# Altium schematic sheet main.SchDoc(main)
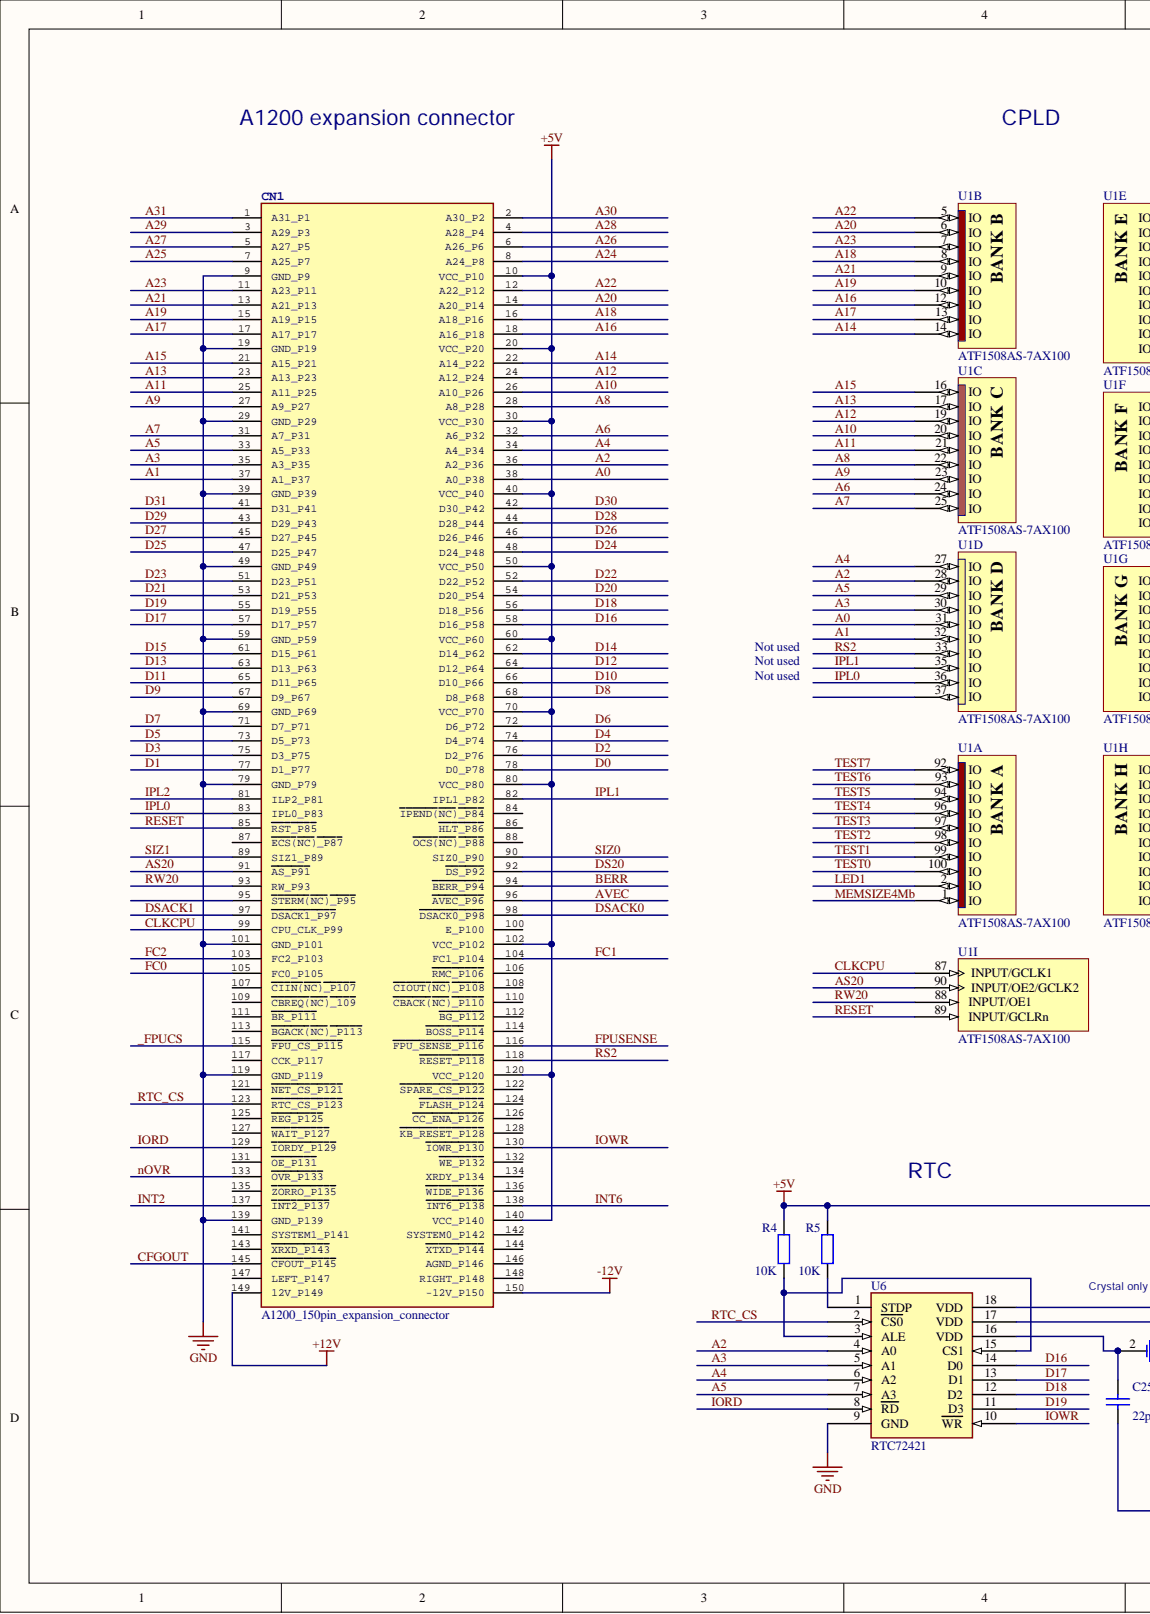
[diagram p0: main.SchDoc, left]
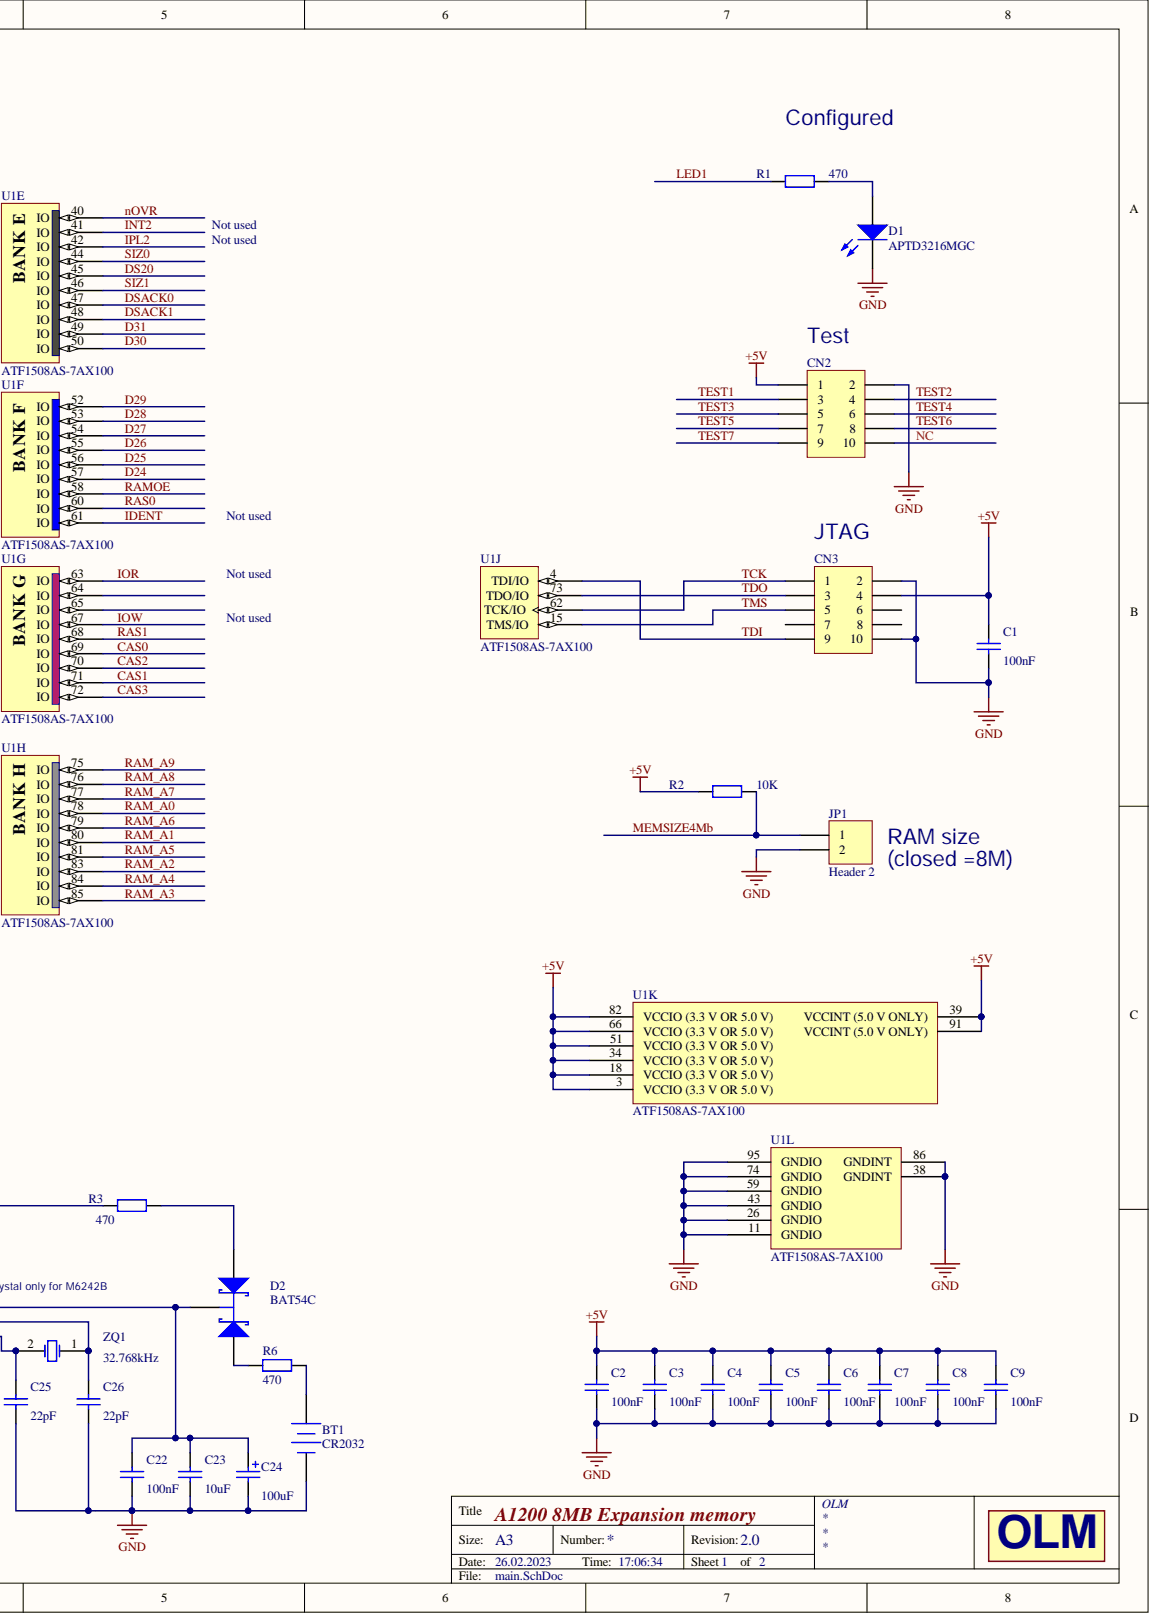
[diagram p1: main.SchDoc, right]

## Parts
BT1  CR2032  [BATTERY_HOLDER_2032]
C1  value 100nF  [SM/C_0603]  (hidden comment: Cap)
C2  value 100nF  [SM/C_0603]  (hidden comment: Cap)
C3  value 100nF  [SM/C_0603]  (hidden comment: Cap)
C4  value 100nF  [SM/C_0603]  (hidden comment: Cap)
C5  value 100nF  [SM/C_0603]  (hidden comment: Cap)
C6  value 100nF  [SM/C_0603]  (hidden comment: Cap)
C7  value 100nF  [SM/C_0603]  (hidden comment: Cap)
C8  value 100nF  [SM/C_0603]  (hidden comment: Cap)
C9  value 100nF  [SM/C_0603]  (hidden comment: Cap)
C22  value 100nF  [SM/C_0603]  (hidden comment: Cap)
C23  value 10uF  [SM/C_1206]  (hidden comment: Cap)
C24  value 100uF  [CAP_POL_CYL_5x11]  (hidden comment: Cap Pol)
C25  value 22pF  [SM/C_0603]  (hidden comment: Capacitor)
C26  value 22pF  [SM/C_0603]  (hidden comment: Capacitor)
CN1  value A1200_150pin_expansion_connector  [A1200_CON150]  (hidden comment: A1200-EXPANSION_SLOT)
CN2  Header 5X2H  [PLD-10]  (value not drawn)
CN3  Header 5X2H  [Header-2x5_2.54mm_RA]  (value not drawn)
D1  value APTD3216MGC  [SM/LED_0805_21_BLUE]  (hidden comment: Светодиод)
D2  BAT54C  [SM/SOT23_123]
JP1  Header 2  [HDR1X2]
R1  value 470  [SM/R_0603]  (hidden comment: Резистор)
R2  value 10K  [SM/R_0603]  (hidden comment: Резистор)
R3  value 470  [SM/R_0603]  (hidden comment: Резистор)
R4  value 10K  [SM/R_0603]  (hidden comment: Резистор)
R5  value 10K  [SM/R_0603]  (hidden comment: Резистор)
R6  value 470  [SM/R_0603]  (hidden comment: Резистор)
U1  ATF1508AS-7AX100  [TQFP100_16mm]  (parts A,B,C,D,E,F,G,H,I,J,K,L)
U6  RTC72421  [DIP.100/18/W.300/L.900]
ZQ1  value 32.768kHz  [CRYSTAL_CLOCK]  (hidden comment: XTAL)

## Nets
+5V: C1.2 C2.2 C3.2 C4.2 C5.2 C6.2 C7.2 C8.2 C9.2 CN1.10(VCC_P10) CN1.20(VCC_P20) CN1.30(VCC_P30) CN1.40(VCC_P40) CN1.50(VCC_P50) CN1.60(VCC_P60) CN1.70(VCC_P70) CN1.80(VCC_P80) CN1.102(VCC_P102) CN1.120(VCC_P120) CN1.140(VCC_P140) CN2.1 CN3.4 R2.2 R3.2 R4.1 R5.1 U1.3(VCCIO_(3.3_V_OR_5.0_V)) U1.18(VCCIO_(3.3_V_OR_5.0_V)) U1.34(VCCIO_(3.3_V_OR_5.0_V)) U1.39(VCCINT_(5.0_V_ONLY)) U1.51(VCCIO_(3.3_V_OR_5.0_V)) U1.66(VCCIO_(3.3_V_OR_5.0_V)) U1.82(VCCIO_(3.3_V_OR_5.0_V)) U1.91(VCCINT_(5.0_V_ONLY))
+12V: CN1.149(12V_P149)
-12V: CN1.150(-12V_P150)
GND: BT1.2 C1.1 C2.1 C3.1 C4.1 C5.1 C6.1 C7.1 C8.1 C9.1 C22.1 C23.1 C24.2 C25.1 C26.1 CN1.9(GND_P9) CN1.19(GND_P19) CN1.29(GND_P29) CN1.39(GND_P39) CN1.49(GND_P49) CN1.59(GND_P59) CN1.69(GND_P69) CN1.79(GND_P79) CN1.101(GND_P101) CN1.119(GND_P119) CN1.139(GND_P139) CN2.2 CN3.2 CN3.10 D1.2 JP1.2 U1.11(GNDIO) U1.26(GNDIO) U1.38(GNDINT) U1.43(GNDIO) U1.59(GNDIO) U1.74(GNDIO) U1.86(GNDINT) U1.95(GNDIO) U6.9(GND)
_FPUCS: CN1.115(FPU_CS_P115)
A0: CN1.38(A0_P38) U1.31(IO)
A1: CN1.37(A1_P37) U1.32(IO)
A2: CN1.36(A2_P36) U1.28(IO) U6.4(A0)
A3: CN1.35(A3_P35) U1.30(IO) U6.5(A1)
A4: CN1.34(A4_P34) U1.27(IO) U6.6(A2)
A5: CN1.33(A5_P33) U1.29(IO) U6.7(A3)
A6: CN1.32(A6_P32) U1.24(IO)
A7: CN1.31(A7_P31) U1.25(IO)
A8: CN1.28(A8_P28) U1.22(IO)
A9: CN1.27(A9_P27) U1.23(IO)
A10: CN1.26(A10_P26) U1.20(IO)
A11: CN1.25(A11_P25) U1.21(IO)
A12: CN1.24(A12_P24) U1.19(IO)
A13: CN1.23(A13_P23) U1.17(IO)
A14: CN1.22(A14_P22) U1.14(IO)
A15: CN1.21(A15_P21) U1.16(IO)
A16: CN1.18(A16_P18) U1.12(IO)
A17: CN1.17(A17_P17) U1.13(IO)
A18: CN1.16(A18_P16) U1.8(IO)
A19: CN1.15(A19_P15) U1.10(IO)
A20: CN1.14(A20_P14) U1.6(IO)
A21: CN1.13(A21_P13) U1.9(IO)
A22: CN1.12(A22_P12) U1.5(IO)
A23: CN1.11(A23_P11) U1.7(IO)
A24: CN1.8(A24_P8)
A25: CN1.7(A25_P7)
A26: CN1.6(A26_P6)
A27: CN1.5(A27_P5)
A28: CN1.4(A28_P4)
A29: CN1.3(A29_P3)
A30: CN1.2(A30_P2)
A31: CN1.1(A31_P1)
AS20: CN1.91(AS_P91) U1.90(INPUT/OE2/GCLK2)
AVEC: CN1.96(AVEC_P96)
BERR: CN1.94(BERR_P94)
CAS0: U1.69(IO)
CAS1: U1.71(IO)
CAS2: U1.70(IO)
CAS3: U1.72(IO)
CFGOUT: CN1.145(CFOUT_P145)
CLKCPU: CN1.99(CPU_CLK_P99) U1.87(INPUT/GCLK1)
D0: CN1.78(D0_P78)
D1: CN1.77(D1_P77)
D2: CN1.76(D2_P76)
D3: CN1.75(D3_P75)
D4: CN1.74(D4_P74)
D5: CN1.73(D5_P73)
D6: CN1.72(D6_P72)
D7: CN1.71(D7_P71)
D8: CN1.68(D8_P68)
D9: CN1.67(D9_P67)
D10: CN1.66(D10_P66)
D11: CN1.65(D11_P65)
D12: CN1.64(D12_P64)
D13: CN1.63(D13_P63)
D14: CN1.62(D14_P62)
D15: CN1.61(D15_P61)
D16: CN1.58(D16_P58) U6.14(D0)
D17: CN1.57(D17_P57) U6.13(D1)
D18: CN1.56(D18_P56) U6.12(D2)
D19: CN1.55(D19_P55) U6.11(D3)
D20: CN1.54(D20_P54)
D21: CN1.53(D21_P53)
D22: CN1.52(D22_P52)
D23: CN1.51(D23_P51)
D24: CN1.48(D24_P48) U1.57(IO)
D25: CN1.47(D25_P47) U1.56(IO)
D26: CN1.46(D26_P46) U1.55(IO)
D27: CN1.45(D27_P45) U1.54(IO)
D28: CN1.44(D28_P44) U1.53(IO)
D29: CN1.43(D29_P43) U1.52(IO)
D30: CN1.42(D30_P42) U1.50(IO)
D31: CN1.41(D31_P41) U1.49(IO)
DS20: CN1.92(DS_P92) U1.45(IO)
DSACK0: CN1.98(DSACK0_P98) U1.47(IO)
DSACK1: CN1.97(DSACK1_P97) U1.48(IO)
FC0: CN1.105(FC0_P105)
FC1: CN1.104(FC1_P104)
FC2: CN1.103(FC2_P103)
FPUSENSE: CN1.116(FPU_SENSE_P116)
IDENT: U1.61(IO)
INT2: CN1.137(INT2_P137) U1.41(IO)
INT6: CN1.138(INT6_P138)
IOR: U1.63(IO)
IORD: CN1.129(IORDY_P129) U6.8(RD)
IOW: U1.67(IO)
IOWR: CN1.130(IOWR_P130) U6.10(WR)
IPL0: CN1.83(IPL0_P83) U1.36(IO)
IPL1: CN1.82(IPL1_P82) U1.35(IO)
IPL2: CN1.81(ILP2_P81) U1.42(IO)
LED1: R1.2 U1.2(IO)
MEMSIZE4MB: JP1.1 R2.1 U1.1(IO)
NC: CN2.10
NOVR: CN1.133(OVR_P133) U1.40(IO)
RAM_A0: U1.78(IO)
RAM_A1: U1.80(IO)
RAM_A2: U1.83(IO)
RAM_A3: U1.85(IO)
RAM_A4: U1.84(IO)
RAM_A5: U1.81(IO)
RAM_A6: U1.79(IO)
RAM_A7: U1.77(IO)
RAM_A8: U1.76(IO)
RAM_A9: U1.75(IO)
RAMOE: U1.58(IO)
RAS0: U1.60(IO)
RAS1: U1.68(IO)
RESET: CN1.85(RST_P85) U1.89(INPUT/GCLRn)
RS2: CN1.118(RESET_P118) U1.33(IO)
RTC_CS: CN1.123(RTC_CS_P123) U6.2(CS0)
RW20: CN1.93(RW_P93) U1.88(INPUT/OE1)
SIZ0: CN1.90(SIZ0_P90) U1.44(IO)
SIZ1: CN1.89(SIZ1_P89) U1.46(IO)
TCK: CN3.1 U1.62(TCK/IO)
TDI: CN3.9 U1.4(TDI/IO)
TDO: CN3.3 U1.73(TDO/IO)
TEST0: U1.100(IO)
TEST1: CN2.3 U1.99(IO)
TEST2: CN2.4 U1.98(IO)
TEST3: CN2.5 U1.97(IO)
TEST4: CN2.6 U1.96(IO)
TEST5: CN2.7 U1.94(IO)
TEST6: CN2.8 U1.93(IO)
TEST7: CN2.9 U1.92(IO)
TMS: CN3.5 U1.15(TMS/IO)
NetBT1_1: BT1.1 R6.1
NetC22_2: C22.2 C23.2 C24.1 D2.3 U6.18(VDD)
NetC25_2: C25.2 U6.16(VDD) ZQ1.2
NetC26_2: C26.2 U6.17(VDD) ZQ1.1
NetD1_1: D1.1 R1.1
NetD2_1: D2.1 R3.1
NetD2_2: D2.2 R6.2
NetR4_2: R4.2 U6.3(ALE) U6.15(CS1)
NetR5_2: R5.2 U6.1(STDP)

Not connected: CN1 84(IPEND(NC)_P84) 86(HLT_P86) 87(ECS(NC)_P87) 88(OCS(NC)_P88) 95(STERM(NC)_P95) 100(E_P100) 106(RMC_P106) 107(CIIN(NC)_P107) 108(CIOUT(NC)_P108) 109(CBREQ(NC)_109) 110(CBACK(NC)_P110) 111(BR_P111) 112(BG_P112) 113(BGACK(NC)_P113) 114(BOSS_P114) 117(CCK_P117) 121(NET_CS_P121) 122(SPARE_CS_P122) 124(FLASH_P124) 125(REG_P125) 126(CC_ENA_P126) 127(WAIT_P127) 128(KB_RESET_P128) 131(OE_P131) 132(WE_P132) 134(XRDY_P134) 135(ZORRO_P135) 136(WIDE_P136) 141(SYSTEM1_P141) 142(SYSTEM0_P142) 143(XRXD_P143) 144(XTXD_P144) 146(AGND_P146) 147(LEFT_P147) 148(RIGHT_P148); CN3 6 7 8; U1 37(IO) 64(IO) 65(IO)

## Library data of the parts
BT1 = Battery: Multicell Battery; Component Kind: Standard; LatestRevisionDate: 17-Jul-2002; LatestRevisionNote: Re-released for DXP Platform.; Library Name: Miscellaneous Devices.IntLib; PackageDescription: Battery; 2 Leads; PackageReference: BAT-2; Published: 24-Mar-1999; Publisher: Altium Limited
C1-C9 C22 C23 = Cap ceramic: CAP-100Nf; Component Kind: Standard; Library Name: New.SCHLIB
C24 = Cap Pol2: Polarized Capacitor (Axial); Component Kind: Standard; LatestRevisionDate: 17-Jul-2002; LatestRevisionNote: Re-released for DXP Platform.; Library Name: Miscellaneous Devices.IntLib; PackageDescription: Polarized Capacitor; 2 Leads; PackageReference: POLAR0.8; Published: 8-Jun-2000; Signal Integrity: Cap Pol; Simulation: CAP; Publisher: Altium Limited
C25 C26 = Cap ceramic: Ceramic capacitor SMD; Manufacturer: Samsung; Component Kind: Standard; Library Name: New.SCHLIB
CN2 CN3 = Header 5X2H: Header, 5-Pin, Dual row, Right Angle; Component Kind: Standard; LatestRevisionDate: 17-Jul-2002; LatestRevisionNote: Re-released for DXP Platform.; Library Name: Miscellaneous Connectors.IntLib; Signal Integrity: Connector; Publisher: Altium Limited
D1 = LED0: Typical INFRARED GaAs LED; Примечание: Kingbright; Component Kind: Standard; LatestRevisionDate: 08-Jul-2005; LatestRevisionNote: Mech Layer 1 removed.; Library Name: Miscellaneous Devices.IntLib; PackageDescription: LED; 2 Leads; PackageReference: LED-0; Published: 8-Jun-2000; Publisher: Altium Limited
D2 = BAT54C: Schottky Barrier Double Diode; Component Kind: Standard; ComponentLink1Description: Manufacturer Link; ComponentLink1URL: http://www.semiconductors.philips.com/; ComponentLink2Description: Datasheet; ComponentLink2URL: http://www.semiconductors.philips.com/acrobat/datasheets/BAT54_SERIES_4.pdf; DatasheetVersion: 4-Mar-2002; Library Name: Philips Discrete Diode - Schottky.IntLib; PackageDescription: SOT-23; 3 Leads; Body 3.0 x 2.5 mm, inc. leads (LxW max); PackageReference: SOT23; PackageVersion: May-1999; Published: 17-Sep-2003; Signal Integrity: BAT54C; Simulation: BAT54C; Publisher: Altium Limited
JP1 = Header 2: Header, 2-Pin; Component Kind: Standard; LatestRevisionDate: 17-Jul-2002; LatestRevisionNote: Re-released for DXP Platform.; Library Name: Miscellaneous Connectors.IntLib; Signal Integrity: Connector; Publisher: Altium Limited
R1-R6 = Res2: Resistor; Component Kind: Standard; LatestRevisionDate: 17-Jul-2002; LatestRevisionNote: Re-released for DXP Platform.; Library Name: Miscellaneous Devices.IntLib; PackageReference: AXIAL-0.4; Published: 8-Jun-2000; Signal Integrity: Res; Simulation: RESISTOR; Publisher: Altium Limited
U1 = EPM7128STC100-7: MAX 7000S 5V In-System Programmable CPLD, 128 Macrocells, 100-Pin TQFP, Speed Grade 7, Commercial Grade; Component Kind: Standard; ComponentLink2Description: Datasheet; ComponentLink3Description: Packaging Specification; ComponentLink3URL: http://www.altera.com/literature/ds/dspkg.pdf; Library Name: Altera MAX 7000S.IntLib; PackageReference: TQFP100; PCB3D: EPM7128S-TQFP100; Signal Integrity: 7k; Supplier 1: Digi-Key; Supplier Part Number 1: 544-1785-ND; Value: ATF1508AS-7AX100
U6 = RTC72421: RTC IC; Component Kind: Standard; Library Name: New_home.SCHLIB; Value: RTC72421
ZQ1 = XTAL: Crystal Oscillator; Part: NX3215SA-32.768K-STD-MUA-14; Component Kind: Standard; LatestRevisionDate: 17-Jul-2002; LatestRevisionNote: Re-released for DXP Platform.; Library Name: Miscellaneous Devices.IntLib; PackageDescription: Crystal, Thru-Hole; 2 Leads; Body 3.1 x 8.2 mm (Dia.xH); PackageReference: R38; Published: 8-Jun-2000; Simulation: XTAL; Publisher: Altium Limited
CN1 = A1200-EXPANSION_SLOT: Component Kind: Standard; Library Name: Connectors_home.SchLib
